# Altium schematic sheet Audio_Codec.SchDoc
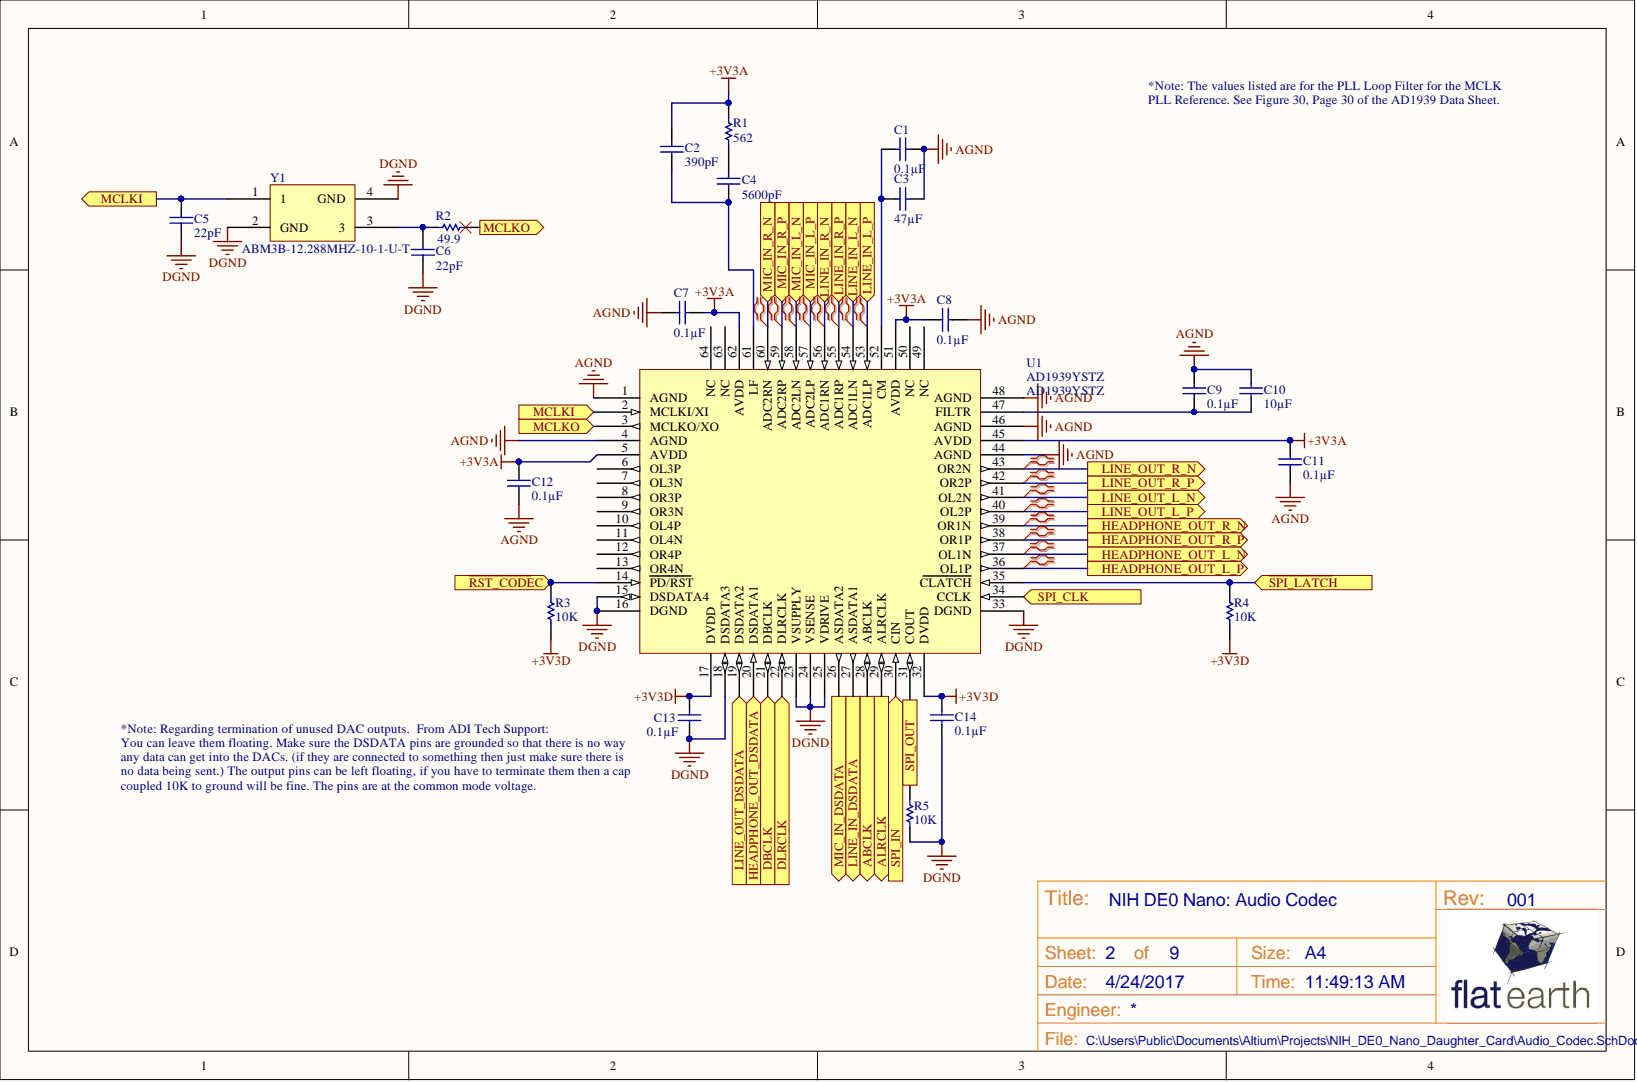
[diagram p0: Audio_Codec.SchDoc]

## Parts
C1  Capacitance: 0.1µF  [3-0603_L]  (hidden comment: C1608X7R1H104K)
C2  Capacitance: 390pF  [3-0603_L]  (hidden comment: CL10B391KB8NNNC)
C3  Capacitance: 47µF  [C1210X25_L]  (hidden comment: GRM32EC81C476KE15L)
C4  Capacitance: 5600pF  [3-0603_L]  (hidden comment: CL10B562KB8SFNC)
C5  Capacitance: 22pF  [3-0603_L]  (hidden comment: C1608C0G1H220J)
C6  Capacitance: 22pF  [3-0603_L]  (hidden comment: C1608C0G1H220J)
C7  Capacitance: 0.1µF  [3-0603_L]  (hidden comment: C1608X7R1H104K)
C8  Capacitance: 0.1µF  [3-0603_L]  (hidden comment: C1608X7R1H104K)
C9  Capacitance: 0.1µF  [3-0603_L]  (hidden comment: C1608X7R1H104K)
C10  Capacitance: 10µF  [3-0603_L]  (hidden comment: C1608X5R1A106K)
C11  Capacitance: 0.1µF  [3-0603_L]  (hidden comment: C1608X7R1H104K)
C12  Capacitance: 0.1µF  [3-0603_L]  (hidden comment: C1608X7R1H104K)
C13  Capacitance: 0.1µF  [3-0603_L]  (hidden comment: C1608X7R1H104K)
C14  Capacitance: 0.1µF  [3-0603_L]  (hidden comment: C1608X7R1H104K)
R1  ERJ-3EKF5620V  [3-0603_R_L]  (value not drawn)
R2  Resistance (Ohms): 49.9  [3-0603_R_L]  (hidden comment: ERJ-3EKF49R9V)
R3  Resistance (Ohms): 10K  [3-0603_R_L]  (hidden comment: ERJ-3GEYJ103V)
R4  Resistance (Ohms): 10K  [3-0603_R_L]  (hidden comment: ERJ-3GEYJ103V)
R5  Resistance (Ohms): 10K  [3-0603_R_L]  (hidden comment: ERJ-3GEYJ103V)
U1  AD1939YSTZ  [ST_64_2]
Y1  ABM3B-12.288MHZ-10-1-U-T  [ADM3B]

## Ports
ABCLK, ALRCLK, DBCLK, DLRCLK, HEADPHONE_OUT_DSDATA, HEADPHONE_OUT_L_N, HEADPHONE_OUT_L_P, HEADPHONE_OUT_R_N, HEADPHONE_OUT_R_P, LINE_IN_DSDATA, LINE_IN_L_N, LINE_IN_L_P, LINE_IN_R_N, LINE_IN_R_P, LINE_OUT_DSDATA, LINE_OUT_L_N, LINE_OUT_L_P, LINE_OUT_R_N, LINE_OUT_R_P, MCLKI, MCLKO, MIC_IN_DSDATA, MIC_IN_L_N, MIC_IN_L_P, MIC_IN_R_N, MIC_IN_R_P, SPI_CLK, SPI_IN, SPI_OUT, ~{RST_CODE}C, ~{SPI_LATCH}

## Nets
+3V3A: C2.1 C7.1 C8.1 C11.1 C12.1 R1.1 U1.5(AVDD) U1.45(AVDD) U1.51(AVDD) U1.62(AVDD)
+3V3D: C13.2 C14.2 R3.1 R4.1 U1.17(DVDD) U1.32(DVDD)
AGND: C1.1 C3.2 C7.2 C8.2 C9.1 C10.1 C11.2 C12.2 U1.1(AGND) U1.4(AGND) U1.44(AGND) U1.46(AGND) U1.48(AGND)
DGND: C5.1 C6.1 C13.1 C14.1 R5.1 U1.15(DSDATA4) U1.16(DGND) U1.18(DSDATA3) U1.23(VSUPPLY) U1.24(VSENSE) U1.25(VDRIVE) U1.33(DGND) Y1.2(GND) Y1.4(GND)
~{RST_CODE}C: R3.2 U1.14(PD/RST) ; port ~{RST_CODE}C
ABCLK: U1.28(ABCLK) ; port ABCLK
ALRCLK: U1.29(ALRCLK) ; port ALRCLK
DBCLK: U1.21(DBCLK) ; port DBCLK
DLRCLK: U1.22(DLRCLK) ; port DLRCLK
HEADPHONE_OUT_DSDATA: U1.20(DSDATA1) ; port HEADPHONE_OUT_DSDATA
HEADPHONE_OUT_L_N: U1.37(OL1N) ; port HEADPHONE_OUT_L_N
HEADPHONE_OUT_L_P: U1.36(OL1P) ; port HEADPHONE_OUT_L_P
HEADPHONE_OUT_R_N: U1.39(OR1N) ; port HEADPHONE_OUT_R_N
HEADPHONE_OUT_R_P: U1.38(OR1P) ; port HEADPHONE_OUT_R_P
LINE_IN_DSDATA: U1.27(ASDATA1) ; port LINE_IN_DSDATA
LINE_IN_L_N: U1.54(ADC1LN) ; port LINE_IN_L_N
LINE_IN_L_P: U1.53(ADC1LP) ; port LINE_IN_L_P
LINE_IN_R_N: U1.56(ADC1RN) ; port LINE_IN_R_N
LINE_IN_R_P: U1.55(ADC1RP) ; port LINE_IN_R_P
LINE_OUT_DSDATA: U1.19(DSDATA2) ; port LINE_OUT_DSDATA
LINE_OUT_L_N: U1.41(OL2N) ; port LINE_OUT_L_N
LINE_OUT_L_P: U1.40(OL2P) ; port LINE_OUT_L_P
LINE_OUT_R_N: U1.43(OR2N) ; port LINE_OUT_R_N
LINE_OUT_R_P: U1.42(OR2P) ; port LINE_OUT_R_P
MCLKI: C5.2 U1.2(MCLKI/XI) Y1.1 ; port MCLKI
MCLKO: R2.2 U1.3(MCLKO/XO) ; port MCLKO
MIC_IN_DSDATA: U1.26(ASDATA2) ; port MIC_IN_DSDATA
MIC_IN_L_N: U1.58(ADC2LN) ; port MIC_IN_L_N
MIC_IN_L_P: U1.57(ADC2LP) ; port MIC_IN_L_P
MIC_IN_R_N: U1.60(ADC2RN) ; port MIC_IN_R_N
MIC_IN_R_P: U1.59(ADC2RP) ; port MIC_IN_R_P
~{SPI_LATCH}: R4.2 U1.35(CLATCH) ; port ~{SPI_LATCH}
SPI_CLK: U1.34(CCLK) ; port SPI_CLK
SPI_IN: U1.30(CIN) ; port SPI_IN
SPI_OUT: R5.2 U1.31(COUT) ; port SPI_OUT
NetC1_2: C1.2 C3.1 U1.52(CM)
NetC2_2: C2.2 C4.2 U1.61(LF)
NetC4_1: C4.1 R1.2
NetC6_2: C6.2 R2.1 Y1.3
NetC9_2: C9.2 C10.2 U1.47(FILTR)

Not connected: U1 6(OL3P) 7(OL3N) 8(OR3P) 9(OR3N) 10(OL4P) 11(OL4N) 12(OR4P) 13(OR4N) 49(NC) 50(NC) 63(NC) 64(NC)

## Library data of the parts
C1 C7-C9 C11-C14 = C1608X7R1H104K: CAP CER 0.1UF 50V 10% X7R 0603; Manufacturer: TDK Corporation; Applications: General Purpose; Category: Capacitors; Component Kind: Standard; ComponentLink1Description: http://www.tdk.com/pdf/general_B11.pdf; ComponentLink1URL: http://www.tdk.com/pdf/general_B11.pdf; Features: -; Height - Seated (Max): -; Lead Spacing: -; Lead Style: -; Library Name: Schematic_Components.SchLib; Manufacturer Part Number: C1608X7R1H104K; Mounting Type: Surface Mount, MLCC; Operating Temperature: -55°C ~ 125°C; Package / Case: 0603 (1608 Metric); Packaging: Cut Tape (CT); Pricing 1: 10=0.048, 100=0.022, 250=0.018, 500=0.0154, 1000=0.012 (USD); Ratings: -; RoHS: RoHS Compliant; Size / Dimension: 0.063" L x 0.031" W (1.60mm x 0.80mm); Stock 1: 2442296; Supplier 1: Digi-Key; Supplier Part Number 1: 445-1314-1-ND; Temperature Coefficient: X7R; Thickness (Max): 0.037" (0.95mm); Tolerance: ±10%; Voltage - Rated: 50V
C2 = CL10B391KB8NNNC: 390pF 50V Ceramic Capacitor X7R 0603 (1608 Metric) 0.063" L x 0.031" W (1.60mm x 0.80mm); Manufacturer: Samsung Electro-Mechanics America, Inc.; Tolerance: ±10%; Applications: General Purpose; Category: Capacitors; Component Kind: Standard; ComponentLink1Description: http://www.samsungsem.com/kr/support/product-search/mlcc/__icsFiles/afieldfile/2016/08/18/S_CL10B391KB8NNNC.pdf; ComponentLink1URL: http://www.samsungsem.com/kr/support/product-search/mlcc/__icsFiles/afieldfile/2016/08/18/S_CL10B391KB8NNNC.pdf; Features: -; Height - Seated (Max): -; Lead Spacing: -; Lead Style: -; Library Name: Schematic_Components.SchLib; Manufacturer Part Number: CL10B391KB8NNNC; Mounting Type: Surface Mount, MLCC; Operating Temperature: -55°C ~ 125°C; Package / Case: 0603 (1608 Metric); Packaging: Cut Tape (CT); Part Status: Active; Pricing 1: 1=0.1, 10=0.035, 25=0.0248, 50=0.0192, 100=0.0162, 500=0.01132, 1000=0.00882 (USD); Ratings: -; RoHS: RoHS Compliant; Size / Dimension: 0.063" L x 0.031" W (1.60mm x 0.80mm); Stock 1: 10890; Supplier 1: Digi-Key; Supplier Part Number 1: 1276-2049-1-ND; Temperature Coefficient: X7R; Thickness (Max): 0.035" (0.90mm); Voltage - Rated: 50V
C3 = GRM32EC81C476KE15L: 47µF ±10% 16V Ceramic Capacitor X6S 1210 (3225 Metric); Manufacturer: Murata Electronics North America; Applications: General Purpose; Category: Capacitors; Component Kind: Standard; ComponentLink1Description: http://www.murata.com/~/media/webrenewal/support/library/catalog/products/capacitor/mlcc/c02e.pdf; ComponentLink1URL: http://www.murata.com/~/media/webrenewal/support/library/catalog/products/capacitor/mlcc/c02e.pdf; Features: Derating Recommended; Height - Seated (Max): -; Lead Spacing: -; Lead Style: -; Library Name: Schematic_Components.SchLib; Manufacturer Part Number: GRM32EC81C476KE15L; Mounting Type: Surface Mount, MLCC; Operating Temperature: -55°C ~ 105°C; Package / Case: 1210 (3225 Metric); Packaging: Cut Tape (CT); Part Status: Active; Pricing 1: 1=0.73, 10=0.697, 25=0.4944, 50=0.4164, 100=0.3383, 250=0.28108, 500=0.25504 (USD); Ratings: -; RoHS: RoHS Compliant; Size / Dimension: 0.126" L x 0.098" W (3.20mm x 2.50mm); Stock 1: 39641; Supplier 1: Digi-Key; Supplier Part Number 1: 490-10531-1-ND; Temperature Coefficient: X6S; Thickness (Max): 0.106" (2.70mm); Tolerance: ±10%; Voltage - Rated: 16V
C4 = CL10B562KB8SFNC: 5600pF 50V Ceramic Capacitor X7R 0603 (1608 Metric) 0.063" L x 0.031" W (1.60mm x 0.80mm); Manufacturer: Samsung Electro-Mechanics America, Inc.; Tolerance: ±10%; Applications: Boardflex Sensitive; Category: Capacitors; Component Kind: Standard; ComponentLink1Description: http://www.samsungsem.com/kr/support/product-search/mlcc/__icsFiles/afieldfile/2016/08/18/S_CL10B562KB8SFNC.pdf; ComponentLink1URL: http://www.samsungsem.com/kr/support/product-search/mlcc/__icsFiles/afieldfile/2016/08/18/S_CL10B562KB8SFNC.pdf; Features: Soft Termination; Height - Seated (Max): -; Lead Spacing: -; Lead Style: -; Library Name: Schematic_Components.SchLib; Manufacturer Part Number: CL10B562KB8SFNC; Mounting Type: Surface Mount, MLCC; Operating Temperature: -55°C ~ 125°C; Package / Case: 0603 (1608 Metric); Packaging: Cut Tape (CT); Part Status: Active; Pricing 1: 1=0.1, 10=0.048, 25=0.034, 50=0.0262, 100=0.0221, 500=0.01548, 1000=0.01206 (USD); Ratings: -; RoHS: RoHS Compliant; Size / Dimension: 0.063" L x 0.031" W (1.60mm x 0.80mm); Stock 1: 35381; Supplier 1: Digi-Key; Supplier Part Number 1: 1276-2092-1-ND; Temperature Coefficient: X7R; Thickness (Max): 0.035" (0.90mm); Voltage - Rated: 50V
C5 C6 = C1608C0G1H220J: CAP CER 22PF 50V 5% NP0 0603; Manufacturer: TDK Corporation; Temperature Coefficient: C0G, NP0; Applications: General Purpose; Category: Capacitors; Component Kind: Standard; ComponentLink1Description: http://www.tdk.com/pdf/general_B11.pdf; ComponentLink1URL: http://www.tdk.com/pdf/general_B11.pdf; Features: -; Height - Seated (Max): -; Lead Spacing: -; Lead Style: -; Library Name: Schematic_Components.SchLib; Manufacturer Part Number: C1608C0G1H220J; Mounting Type: Surface Mount, MLCC; Operating Temperature: -55°C ~ 125°C; Package / Case: 0603 (1608 Metric); Packaging: Cut Tape (CT); Pricing 1: 10=0.03, 100=0.0138, 250=0.01124, 500=0.00962, 1000=0.0075 (USD); Ratings: -; RoHS: RoHS Compliant; Size / Dimension: 0.063" L x 0.031" W (1.60mm x 0.80mm); Stock 1: 1279980; Supplier 1: Digi-Key; Supplier Part Number 1: 445-1273-1-ND; Thickness (Max): 0.037" (0.95mm); Tolerance: ±5%; Voltage - Rated: 50V
C10 = C1608X5R1A106K: CAP CER 10UF 10V 10% X5R 0603; Manufacturer: TDK Corporation; Applications: General Purpose; Category: Capacitors; Component Kind: Standard; ComponentLink1Description: http://www.tdk.com/pdf/general_B11.pdf; ComponentLink1URL: http://www.tdk.com/pdf/general_B11.pdf; Features: -; Height - Seated (Max): -; Lead Spacing: -; Lead Style: -; Library Name: Schematic_Components.SchLib; Manufacturer Part Number: C1608X5R1A106K; Mounting Type: Surface Mount, MLCC; Operating Temperature: -55°C ~ 85°C; Package / Case: 0603 (1608 Metric); Packaging: Cut Tape (CT); Pricing 1: 1=0.48, 10=0.42, 100=0.21, 250=0.168, 500=0.153, 1000=0.138 (USD); Ratings: -; RoHS: RoHS Compliant; Size / Dimension: 0.063" L x 0.031" W (1.60mm x 0.80mm); Stock 1: 36523; Supplier 1: Digi-Key; Supplier Part Number 1: 445-7486-1-ND; Temperature Coefficient: X5R; Thickness (Max): 0.035" (0.90mm); Tolerance: ±10%; Voltage - Rated: 10V
R1 = ERJ-3EKF5620V: RES SMD 562 OHM 1% 1/10W 0603; Manufacturer: Panasonic Electronic Components; Power (Watts): 0.1W, 1/10W; Resistance (Ohms): 562; Temperature Coefficient: ±100ppm/°C; Category: Resistors; Component Kind: Standard; ComponentLink1Description: http://industrial.panasonic.com/www-cgi/jvcr13pz.cgi?E+PZ+3+AOA0002+ERJ3EKF5620V+7+WW; ComponentLink1URL: http://industrial.panasonic.com/www-cgi/jvcr13pz.cgi?E+PZ+3+AOA0002+ERJ3EKF5620V+7+WW; Composition: Thick Film; Failure Rate: -; Features: Automotive AEC-Q200; Height: 0.022" (0.55mm); Library Name: Schematic_Components.SchLib; Manufacturer Part Number: ERJ-3EKF5620V; Number of Terminations: 2; Operating Temperature: -55°C ~ 155°C; Package / Case: 0603 (1608 Metric); Packaging: Cut Tape (CT); Part Status: Active; Pricing 1: 1=0.1, 50=0.0114, 100=0.0084, 250=0.00644, 500=0.00514, 1000=0.00379 (USD); RoHS: RoHS Compliant; Size / Dimension: 0.063" L x 0.031" W (1.60mm x 0.80mm); Stock 1: 336376; Supplier 1: Digi-Key; Supplier Device Package: 0603; Supplier Part Number 1: P562HCT-ND; Tolerance: ±1%
R2 = ERJ-3EKF49R9V: RES SMD 49.9 OHM 1% 1/10W 0603; Manufacturer: Panasonic Electronic Components; Power (Watts): 0.1W, 1/10W; Temperature Coefficient: ±100ppm/°C; Category: Resistors; Component Kind: Standard; ComponentLink1Description: http://industrial.panasonic.com/www-cgi/jvcr13pz.cgi?E+PZ+3+AOA0002+ERJ3EKF49R9V+7+WW; ComponentLink1URL: http://industrial.panasonic.com/www-cgi/jvcr13pz.cgi?E+PZ+3+AOA0002+ERJ3EKF49R9V+7+WW; Composition: Thick Film; Failure Rate: -; Features: Automotive AEC-Q200; Height - Seated (Max): 0.022" (0.55mm); Library Name: Schematic_Components.SchLib; Number of Terminations: 2; Operating Temperature: -55°C ~ 155°C; Package / Case: 0603 (1608 Metric); Packaging: Cut Tape (CT); Part Status: Active; Pricing 1: 1=0.1, 10=0.019, 25=0.0136, 50=0.0104, 100=0.0077, 250=0.00584, 500=0.00468, 1000=0.00345 (USD); RoHS: RoHS Compliant; Size / Dimension: 0.063" L x 0.031" W (1.60mm x 0.80mm); Stock 1: 1715606; Supplier 1: Digi-Key; Supplier Device Package: 0603; Supplier Part Number 1: P49.9HCT-ND; Tolerance: ±1%
R3-R5 = ERJ-3GEYJ103V: RES 10K OHM 1/10W 5% 0603 SMD; Manufacturer: Panasonic - ECG; Power (Watts): 0.1W, 1/10W; Temperature Coefficient: ±200ppm/°C; Category: Resistors; Component Kind: Standard; ComponentLink1Description: http://industrial.panasonic.com/www-cgi/jvcr13pz.cgi?E+PZ+3+AOA0001+ERJ3GEYJ103V+7+WW; ComponentLink1URL: http://industrial.panasonic.com/www-cgi/jvcr13pz.cgi?E+PZ+3+AOA0001+ERJ3GEYJ103V+7+WW; Composition: Thick Film; Features: -; Height: 0.022" (0.55mm); Library Name: Schematic_Components.SchLib; Manufacturer Part Number: ERJ-3GEYJ103V; Number of Terminations: 2; Package / Case: 0603 (1608 Metric); Packaging: Cut Tape (CT); Pricing 1: 1=0.02, 50=0.0092, 100=0.007, 250=0.0052, 500=0.00384, 1000=0.00256 (USD); RoHS: RoHS Compliant; Size / Dimension: 0.063" L x 0.031" W (1.60mm x 0.80mm); Stock 1: 16219504; Supplier 1: Digi-Key; Supplier Part Number 1: P10KGCT-ND; Tolerance: ±5%
U1 = AD1939YSTZ: Single-chip codec that prvides 4 ADCs with differential input and 8 DACs with differential output; Manufacturer: Analog Devices Inc.; Data Interface: Serial; Dynamic Range, ADCs / DACs (db) Typ: 105 / 110; Number of ADCs / DACs: 4 / 8; Resolution (Bits): 24 b; S/N Ratio, ADCs / DACs (db) Typ: 94 / 94; Sigma Delta: Yes; Voltage - Supply, Analog: 3 V ~ 3.6 V; Category: Integrated Circuits (ICs); Component Kind: Standard; ComponentLink1Description: http://www.analog.com/media/en/technical-documentation/data-sheets/AD1939.pdf; ComponentLink1URL: http://www.analog.com/media/en/technical-documentation/data-sheets/AD1939.pdf; Manufacturer Part Number: AD1939YSTZ; Mounting Type: Surface Mount; Operating Temperature: -40°C ~ 105°C; Package / Case: 64-LQFP; Packaging: Tray; Pricing 1: 1=15.81, 10=14.527, 100=12.269, 500=10.91416, 1000=10.01091, 2500=9.63456, 5000=9.33348 (USD); RoHS: RoHS Compliant; Stock 1: 432; Supplier 1: Digi-Key; Supplier Device Package: 64-LQFP (10x10); Supplier Part Number 1: AD1939YSTZ-ND; Type: General Purpose; Voltage - Supply, Digital: 3 V ~ 3.6 V; Library Name: NIH_DE0_Nano_Daughter_Card_Library.SchLib
Y1 = ABM3B-12.288MHZ-10-1-U-T: 12.288MHz ±10ppm Crystal 10pF 70 Ohm -10°C ~ 60°C Surface Mount 4-SMD, No Lead (DFN, LCC); Manufacturer: Abracon LLC; ESR (Equivalent Series Resistance): 70 Ohm; Operating Mode: Fundamental; Category: Crystals and Oscillators; Component Kind: Standard; ComponentLink1Description: http://www.abracon.com/Resonators/abm3b.pdf; ComponentLink1URL: http://www.abracon.com/Resonators/abm3b.pdf; Frequency: 12.288MHz; Frequency Stability: ±10ppm; Frequency Tolerance: ±10ppm; Height: 0.043" (1.10mm); Load Capacitance: 10pF; Manufacturer Part Number: ABM3B-12.288MHZ-10-1-U-T; Mounting Type: Surface Mount; Operating Temperature: -10°C ~ 60°C; Package / Case: 4-SMD, No Lead (DFN, LCC); Packaging: Cut Tape (CT); Part Status: Active; Pricing 1: 1=1.09, 10=0.968, 50=0.904, 100=0.8, 500=0.756 (USD); Ratings: -; RoHS: RoHS Compliant; Size / Dimension: 0.197" L x 0.126" W (5.00mm x 3.20mm); Stock 1: 1220; Supplier 1: Digi-Key; Supplier Part Number 1: 300-8198-1-ND; Type: MHz Crystal; Library Name: NIH_DE0_Nano_Daughter_Card_Library.SchLib
